# Altium schematic sheet STM32WL_MCU_IOs.SchDoc(STM32WL_MCU_IOs)
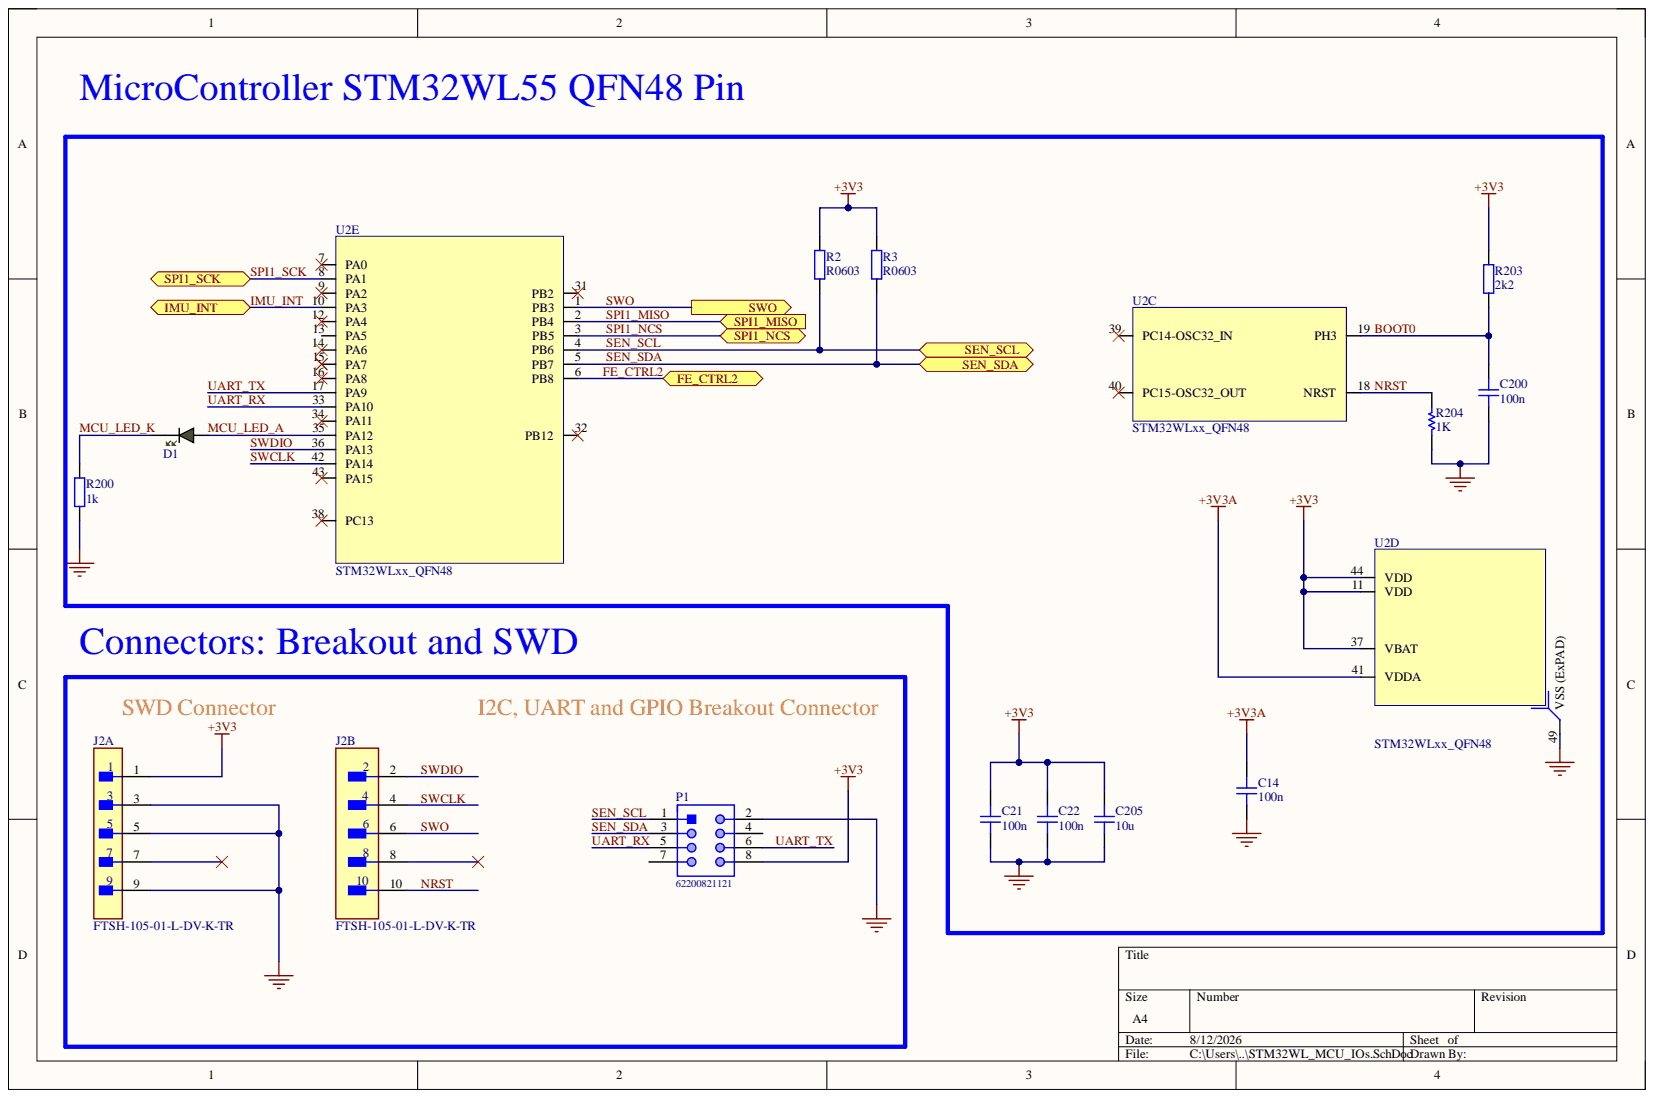
[diagram p0: STM32WL_MCU_IOs.SchDoc]

## Parts
C14  100n  [CAP_0201]
C21  100n  [CAP_0201]
C22  100n  [CAP_0201]
C200  100n  [CAP_0402]
C205  10u  [CAP_0603]
D1  GREEN  [LED_0402_GREEN]  (value not drawn)
J2  FTSH-105-01-L-DV-K-TR  [FP-FTSH-105-01-L-DV-K-TR-MFG]  (parts A,B)
P1  62200821121  [62200821121]
R2  R0603  [RES_0603]
R3  R0603  [RES_0603]
R200  1k  [RES_0402]
R203  2k2  [RES_0402]
R204  value 1K  [R0402]
U2  STM32WLxx_QFN48  [STM32WLxx_QFN48]  (parts C,D,E)

## Ports
FE_CTRL2, IMU_INT, SEN_SCL, SEN_SDA, SPI1_MISO, SPI1_NCS, SPI1_SCK, SWO

## Nets
+3V3: C21.2 C22.2 C205.2 J2.1 P1.8 R2.2 R3.2 R203.2 U2.11(VDD) U2.37(VBAT) U2.44(VDD)
+3V3A: C14.2 U2.41(VDDA)
GND: C14.1 C21.1 C22.1 C200.1 C205.1 J2.3 J2.5 J2.9 P1.2 R200.1 R204.1
BOOT0: C200.2 R203.1 U2.19(PH3)
FE_CTRL2: U2.6(PB8) ; port FE_CTRL2
IMU_INT: U2.10(PA3) ; port IMU_INT
MCU_LED_A: D1.A U2.35(PA12)
MCU_LED_K: D1.K R200.2
NRST: J2.10 R204.2 U2.18(NRST)
SEN_SCL: P1.1 R2.1 U2.4(PB6) ; port SEN_SCL
SEN_SDA: P1.3 R3.1 U2.5(PB7) ; port SEN_SDA
SPI1_MISO: U2.2(PB4) ; port SPI1_MISO
SPI1_NCS: U2.3(PB5) ; port SPI1_NCS
SPI1_SCK: U2.8(PA1) ; port SPI1_SCK
SWCLK: J2.4 U2.42(PA14)
SWDIO: J2.2 U2.36(PA13)
SWO: J2.6 U2.1(PB3) ; port SWO
UART_RX: P1.5 U2.33(PA10)
UART_TX: P1.6 U2.17(PA9)

Not connected: J2 7 8; P1 4 7; U2 7(PA0) 9(PA2) 12(PA4) 13(PA5) 14(PA6) 15(PA7) 16(PA8) 31(PB2) 32(PB12) 34(PA11) 38(PC13) 39(PC14-OSC32_IN) 40(PC15-OSC32_OUT) 43(PA15) 49(VSS_(ExPAD))

## Library data of the parts
C14 C21 C22 = CAP_0201_16V: Capacitor SMD 0201 16V; Component Kind: Standard; Library Name: Schematic-Lib-PhilsLab.SchLib
C200 = CAP_0402_16V: Capacitor SMD 0402 16V; Component Kind: Standard; Library Name: Schematic-Lib-PhilsLab.SchLib
C205 = CAP_0603_16V: Capacitor SMD 0603 16V; Component Kind: Standard; Library Name: Schematic-Lib-PhilsLab.SchLib
D1 = LED_0402_GREEN: LED 0402 GREEN SMD; Component Kind: Standard; Library Name: Schematic-Lib-PhilsLab.SchLib
J2 = CMP-2000-06293-2: CONN HEADER SMD 10POS 1.27MM; Connector Type: Male Pin; Current Rating: 1.75A; Gender: Male; Number of Contacts: 10; Row Spacing: 0.05inch; Color: Black; Component Kind: Standard; Contact Material: Bronze; Contact Mating Length: 0.12inch; Contact Plating: Gold; Depth: 3.43mm; Housing Color: Black; Housing Material: Polymer; Lead Free: Lead Free; Length: 6.35mm; Library Name: Altium Content Vault; Max Current Rating: 1.75A; Max Operating Temperature: 125°C; Min Operating Temperature: -55°C; Mount: Surface Mount; Number of Positions: 10; Number of Rows: 2; Orientation: Straight; Package Quantity: 550; Packaging: Tape and Reel; Pitch: 1.27mm; Radiation Hardening: No; REACH SVHC: No SVHC; Termination: Solder
P1 = CMP-1502-00947-1: THT Vertical Pin Header WR-PHD, Pitch 1.27 mm, Dual Row, 8 pins; Manufacturer: Wurth Elektronik; Contact Resistance: 20mOhm; Gender: Male; Pin Number: 8; Pitch: 1.27mm; Rated Current: 1A; Withstanding Voltage: 500VAC; Working Voltage: 100VAC; Component Kind: Standard; Datasheet URL: https://katalog.we-online.de/em/datasheet/62200821121.pdf; Library Name: Altium Content Vault; Manufacturer URL: http://www.we-online.de; Mounting Technology: THT; Operating Temperature: -40to105degC; Orientation: Vertical; Package Reference: 62200821121; Packaging: Box; Part Number: 62200821121; Row Number: Dual; Publisher: WurthElektronik
R2 R3 = RES_0603: Resistor SMD 0603; Component Kind: Standard; Library Name: Schematic-Lib-PhilsLab.SchLib
R200 R203 = RES_0402: Resistor SMD 0402; Component Kind: Standard; Library Name: Schematic-Lib-PhilsLab.SchLib
R204 = MCD-00061: Resistor,1K,0402,1%,1/16W; Manufacturer: YAGEO; Manufacturer_Part_Number: RC0402FR-071KL; Database Table Name: MCD_PARTS$; ECCN_EU: NEC; ECCN_US: EAR99; Provided_By: SUBCO; Component Kind: Standard; ComponentLink1Description: Datasheet; ComponentLink1URL: http://tunmcdsvn.tun.st.com/Component_Datasheet_Library/Trunk/Resistors/Yageo_Resistor_RC0402-series; Internal_Part_Number: MCD-00061; Library Name: MCD_PARTS_database.DbLib; Package: R0402; Part_Type: Resistor; Status: Released
U2 = STM32WLxx_QFN48: HQDC : Verified April, 25 2019; Manufacturer: STMicroelectronics; Manufacturer_Part_Number: STM32WLE5CC; Component Kind: Standard; Library Name: MB1789_HP.SCHLIB
